# Altium schematic sheet drvinput1.SchDoc(drvinput1)
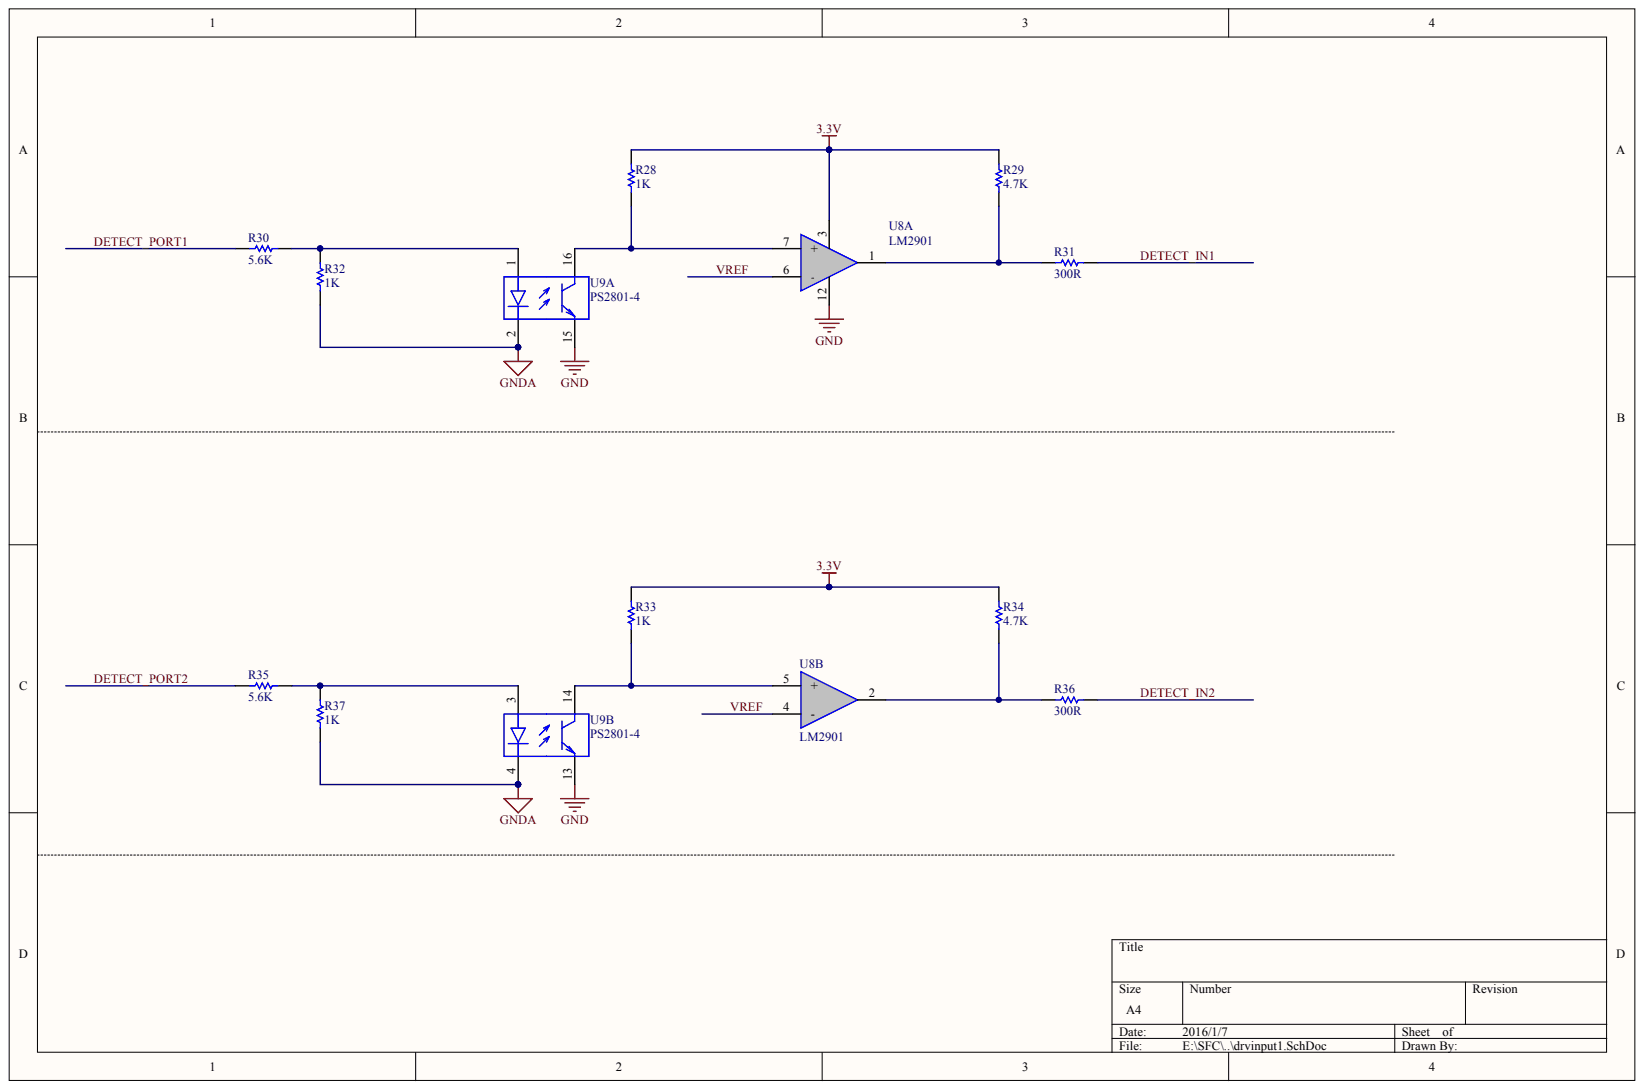
[diagram p0: drvinput1.SchDoc]

## Parts
R28  1K
R29  4.7K
R30  5.6K
R31  300R
R32  1K
R33  1K
R34  4.7K
R35  5.6K
R36  300R
R37  1K
U8  LM2901  (parts A,B)
U9  PS2801-4  (parts A,B)

## Nets
3.3V: R28.1 R29.2 R33.1 R34.2 U8.3
GND: U8.12 U9.13 U9.15
GNDA: R32.1 R37.1 U9.2 U9.4
DETECT_IN1: R31.1
DETECT_IN2: R36.2
DETECT_PORT1: R30.2
DETECT_PORT2: R35.2
VREF: U8.4(-) U8.6(-)
NetR28_2: R28.2 U8.7(+) U9.16
NetR29_1: R29.1 R31.2 U8.1
NetR30_1: R30.1 R32.2 U9.1
NetR33_2: R33.2 U8.5(+) U9.14
NetR34_1: R34.1 R36.1 U8.2
NetR35_1: R35.1 R37.2 U9.3

## Library data of the parts
R28-R35 R37 = (no symbol name): Value: 10K; Published: 8-Jun-2000; LatestRevisionDate: 17-Jul-2002; LatestRevisionNote: Re-released for DXP Platform.; PackageReference: AXIAL-0.3; Publisher: Altium Limited
R36 = (no symbol name): Value: 470; Published: 8-Jun-2000; LatestRevisionDate: 17-Jul-2002; LatestRevisionNote: Re-released for DXP Platform.; PackageReference: AXIAL-0.3; Publisher: Altium Limited
U8 U9 = (no symbol name)
  U9: Published: 28-Feb-2003; LatestRevisionDate: 13-Apr-2006; LatestRevisionNote: IPC-7351 Footprint Added.; DatasheetVersion: Jan-2002, Rev. 7; PackageVersion: rev7, Jan-2002; PackageReference: 751-07; PackageDescription: 8-Pin Plastic Small Outline Integrated Circuit 1.27 mm Pitch; Code_JEDEC: MS-012-AA; Code_IPC: SOIC127P600-8; ComponentLink1Description: Manufacturer Link; ComponentLink1URL: http://www.onsemi.com/; ComponentLink2Description: Datasheet; ComponentLink2URL: http://www.onsemi.com/pub/Collateral/LM358-D.PDF; Publisher: Altium Limited
